# Altium schematic sheet WIFI模块.SchDoc(WIFI模块)
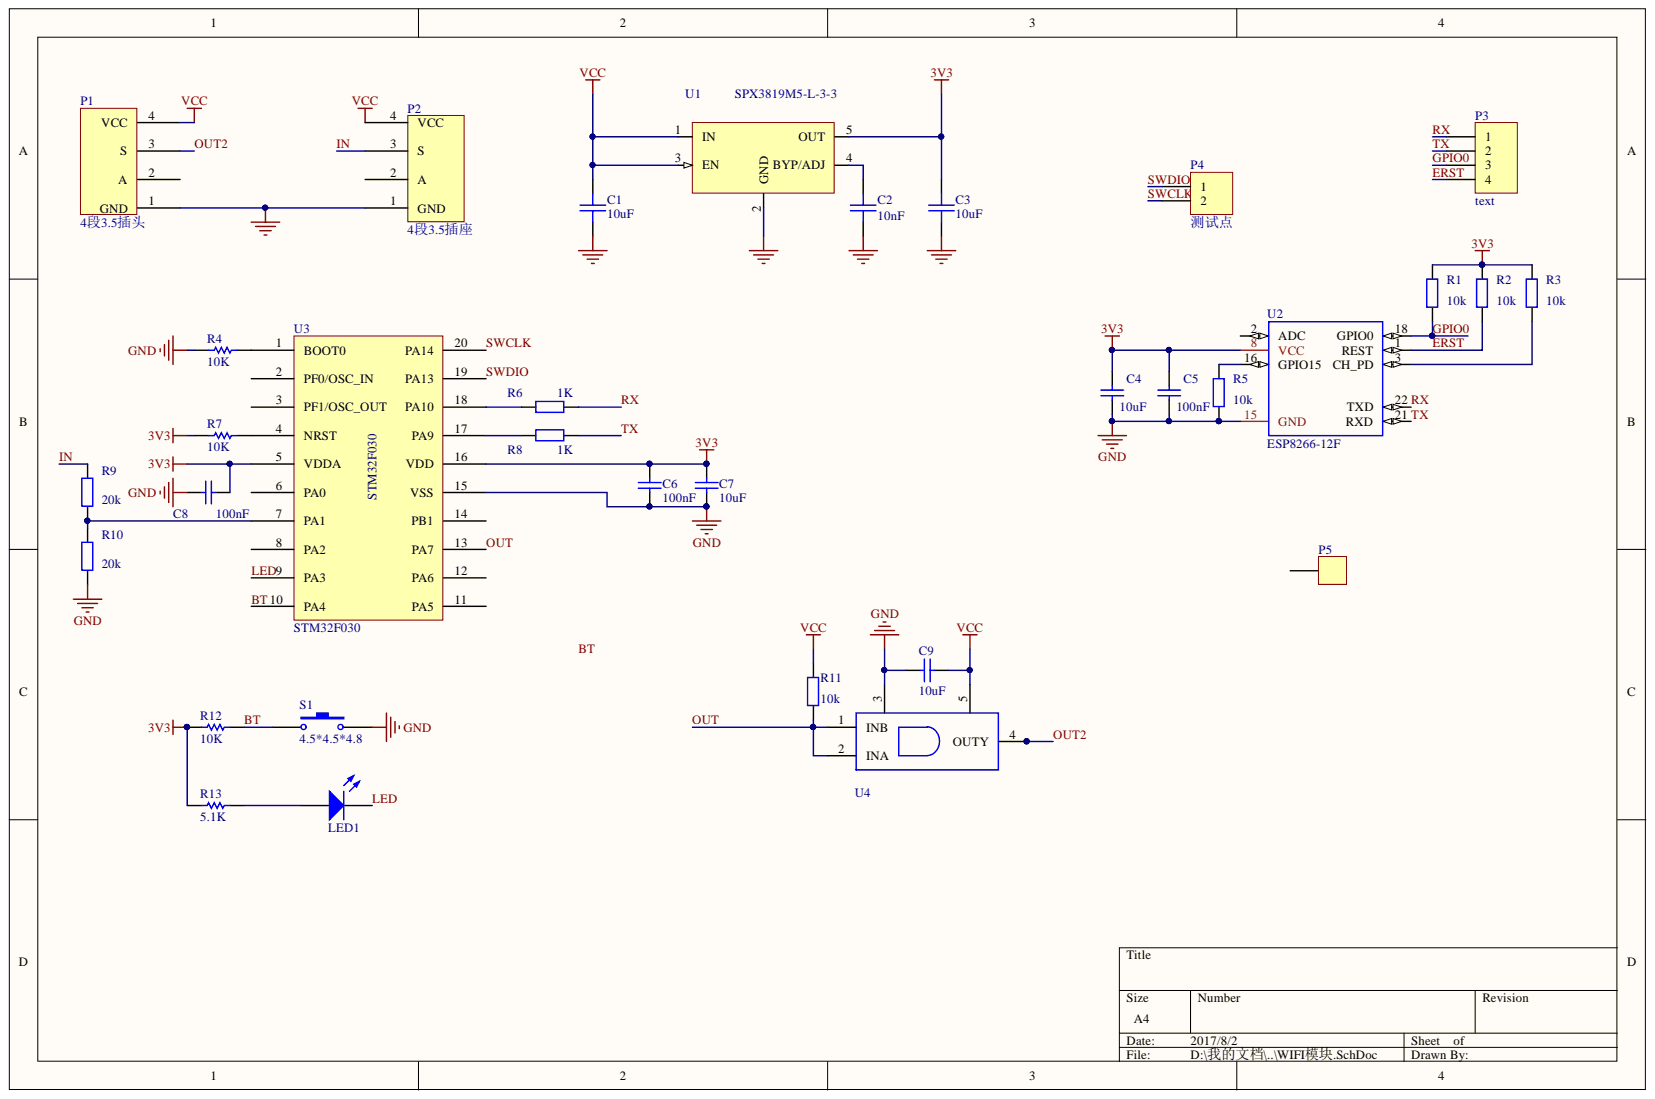
[diagram p0: WIFI模块.SchDoc]

## Parts
C1  10uF  [0603C]
C2  10nF  [0603C]
C3  10uF  [0603C]
C4  10uF  [0603C]
C5  100nF  [0603C]
C6  100nF  [0603C]
C7  10uF  [0603C]
C8  100nF  [0603C]
C9  10uF  [0603C]
LED1  LED  [LC-0603_LED_S1]
P1  4段3.5插头  [耳机头4段3.5mm]
P2  4段3.5插座  [耳机座4段3.5插座]
P3  text
P4  测试点
P5  terminal  [M3固定孔]  (value not drawn)
R1  10k  [0603R]
R2  10k  [0603R]
R3  10k  [0603R]
R4  10K  [0603R]
R5  10k  [0603R]
R6  1K  [0603R]
R7  10K  [0603R]
R8  1K  [0603R]
R9  20k  [0603R]
R10  20k  [0603R]
R11  10k  [0603R]
R12  10K  [0603R]
R13  5.1K  [0603R]
S1  4.5*4.5*4.8  [按键4.5*4.5*4.8]
U1  SPX3819M5-L-3-3  [SOT23-5]
U2  ESP8266-12F  [ESP8266]
U3  STM32F030  [TSSOP20]
U4  74HC1G08  [SOT-353]  (value not drawn)

## Nets
3V3: C3.1 C4.2 C5.2 C6.2 C7.1 C8.2 R1.2 R2.2 R3.2 R7.2 R12.2 R13.2 U1.5(OUT) U2.8(VCC) U3.5(VDDA) U3.16(VDD)
GND: C1.2 C2.2 C3.2 C4.1 C5.1 C6.1 C7.2 C8.1 C9.2 P1.1(GND) P2.1(GND) R4.2 R5.1 R10.1 S1.2 U1.2(GND) U2.15(GND) U3.15(VSS) U4.3
VCC: C1.1 C9.1 P1.4(VCC) P2.4(VCC) R11.1 U1.1(IN) U1.3(EN) U4.5
BT: R12.1 S1.1 U3.10(PA4)
ERST: P3.4 R2.1 U2.1(REST)
GPIO0: P3.3 R1.1 U2.18(GPIO0)
IN: P2.3(S) R9.2
LED: LED1.K U3.9(PA3)
OUT: R11.2 U3.13(PA7) U4.1(INB) U4.2(INA)
OUT2: P1.3(S) U4.4(OUTY)
RX: P3.1 R6.2 U2.22(TXD)
SWCLK: P4.2 U3.20(PA14)
SWDIO: P4.1 U3.19(PA13)
TX: P3.2 R8.2 U2.21(RXD)
NetC2_1: C2.1 U1.4(BYP/ADJ)
NetLED1_A: LED1.A R13.1
NetR3_1: R3.1 U2.3(CH_PD)
NetR4_1: R4.1 U3.1(BOOT0)
NetR5_2: R5.2 U2.16(GPIO15)
NetR6_1: R6.1 U3.18(PA10)
NetR7_1: R7.1 U3.4(NRST)
NetR8_1: R8.1 U3.17(PA9)
NetR9_1: R9.1 R10.2 U3.7(PA1)

Not connected: P1 2(A); P2 2(A); P5 1; U2 2(ADC); U3 2(PF0/OSC_IN) 3(PF1/OSC_OUT) 6(PA0) 8(PA2) 11(PA5) 12(PA6) 14(PB1)

## Library data of the parts
C1-C3 = SMT_C_0402: Surface mount capacitor 0402; Component Kind: Standard; Library Name: Vowstar_Basic_Device.IntLib
C4 C5 C9 = Cap: Value: 100pF; Published: 8-Jun-2000; PackageReference: RAD-0.3; PackageDescription: Radial Cap, Thru-Hole; 2 Leads; 0.3 in Pin Spacing; LatestRevisionNote: Re-released for DXP Platform.; LatestRevisionDate: 17-Jul-2002; Component Kind: Standard; Publisher: Altium Limited; Library Name: 基础元件库.SchLib
C6-C8 = Cap: Capacitor; Value: 100pF; Published: 8-Jun-2000; PackageReference: RAD-0.3; PackageDescription: Radial Cap, Thru-Hole; 2 Leads; 0.3 in Pin Spacing; Library Name: Miscellaneous Devices.IntLib; LatestRevisionNote: Re-released for DXP Platform.; LatestRevisionDate: 17-Jul-2002; Component Kind: Standard; Publisher: Altium Limited
  C7: Simulation: CAP; Signal Integrity: Cap
P3 = Header 4: Header, 4-Pin; Library Name: Miscellaneous Connectors.IntLib; LatestRevisionNote: Re-released for DXP Platform.; LatestRevisionDate: 17-Jul-2002; Component Kind: Standard; Publisher: Altium Limited
P4 = Header 2: Header, 2-Pin; Library Name: Miscellaneous Connectors.IntLib; LatestRevisionNote: Re-released for DXP Platform.; LatestRevisionDate: 17-Jul-2002; Component Kind: Standard; Publisher: Altium Limited
R1-R3 R5 R9-R11 = Res: Value: 1K; Published: 8-Jun-2000; PackageReference: AXIAL-0.4; PackageDescription: Axial Device, Thru-Hole; 2 Leads; 0.4 in Pin Spacing; LatestRevisionNote: Re-released for DXP Platform.; LatestRevisionDate: 17-Jul-2002; Component Kind: Standard; Publisher: Altium Limited; Library Name: 基础元件库.SchLib
R4 R7 R12 R13 = Res1: Resistor; Value: 1K; Simulation: RESISTOR; Signal Integrity: Res; Published: 8-Jun-2000; PackageReference: AXIAL-0.3; PackageDescription: Axial Device, Thru-Hole; 2 Leads; 0.3 in Pin Spacing; Library Name: Miscellaneous Devices.IntLib; LatestRevisionNote: Re-released for DXP Platform.; LatestRevisionDate: 17-Jul-2002; Component Kind: Standard; Publisher: Altium Limited
R6 R8 = Res: Value: 1K; Published: 8-Jun-2000; PackageReference: AXIAL-0.4; PackageDescription: Axial Device, Thru-Hole; 2 Leads; 0.4 in Pin Spacing; LatestRevisionNote: Re-released for DXP Platform.; LatestRevisionDate: 17-Jul-2002; Component Kind: Standard; Publisher: Altium Limited; Library Name: 基础元件库.SchLib
S1 = SW-PB: Switch; Published: 24-Mar-1999; PackageReference: SPST-2; PackageDescription: Switch; 2 Leads; Library Name: Miscellaneous Devices.IntLib; LatestRevisionNote: Re-released for DXP Platform.; LatestRevisionDate: 17-Jul-2002; Component Kind: Standard; Publisher: Altium Limited
U1 = SPX3819: 500mA, Low-Noise LDO Voltage Regulator; Component Kind: Standard; Library Name: Vowstar_IC_General.IntLib
LED1 = LED: Published: 8-Jun-2000; PackageReference: LED-0; PackageDescription: LED; 2 Leads; LatestRevisionNote: Mech Layer 1 removed.; LatestRevisionDate: 08-Jul-2005; Component Kind: Standard; Publisher: Altium Limited; Library Name: 基础元件库.SchLib
P1 = 4段3.5插头: Library Name: DDL6.30.SchLib; Component Kind: Standard
P2 = 4段3.5插座: Library Name: DDL6.30.SchLib; Component Kind: Standard
P5 = terminal: Component Kind: Standard; Library Name: 基础元件库.SchLib
U2 = ESP8266-12F: Component Kind: Standard; Library Name: 基础元件库.SchLib
U3 = stm32f030: Library Name: DDL6.30.SchLib; Component Kind: Standard
U4 = 74HC1G08: Component Kind: Standard; Library Name: 基础元件库.SchLib
